# Altium schematic sheet MCU.SchDoc
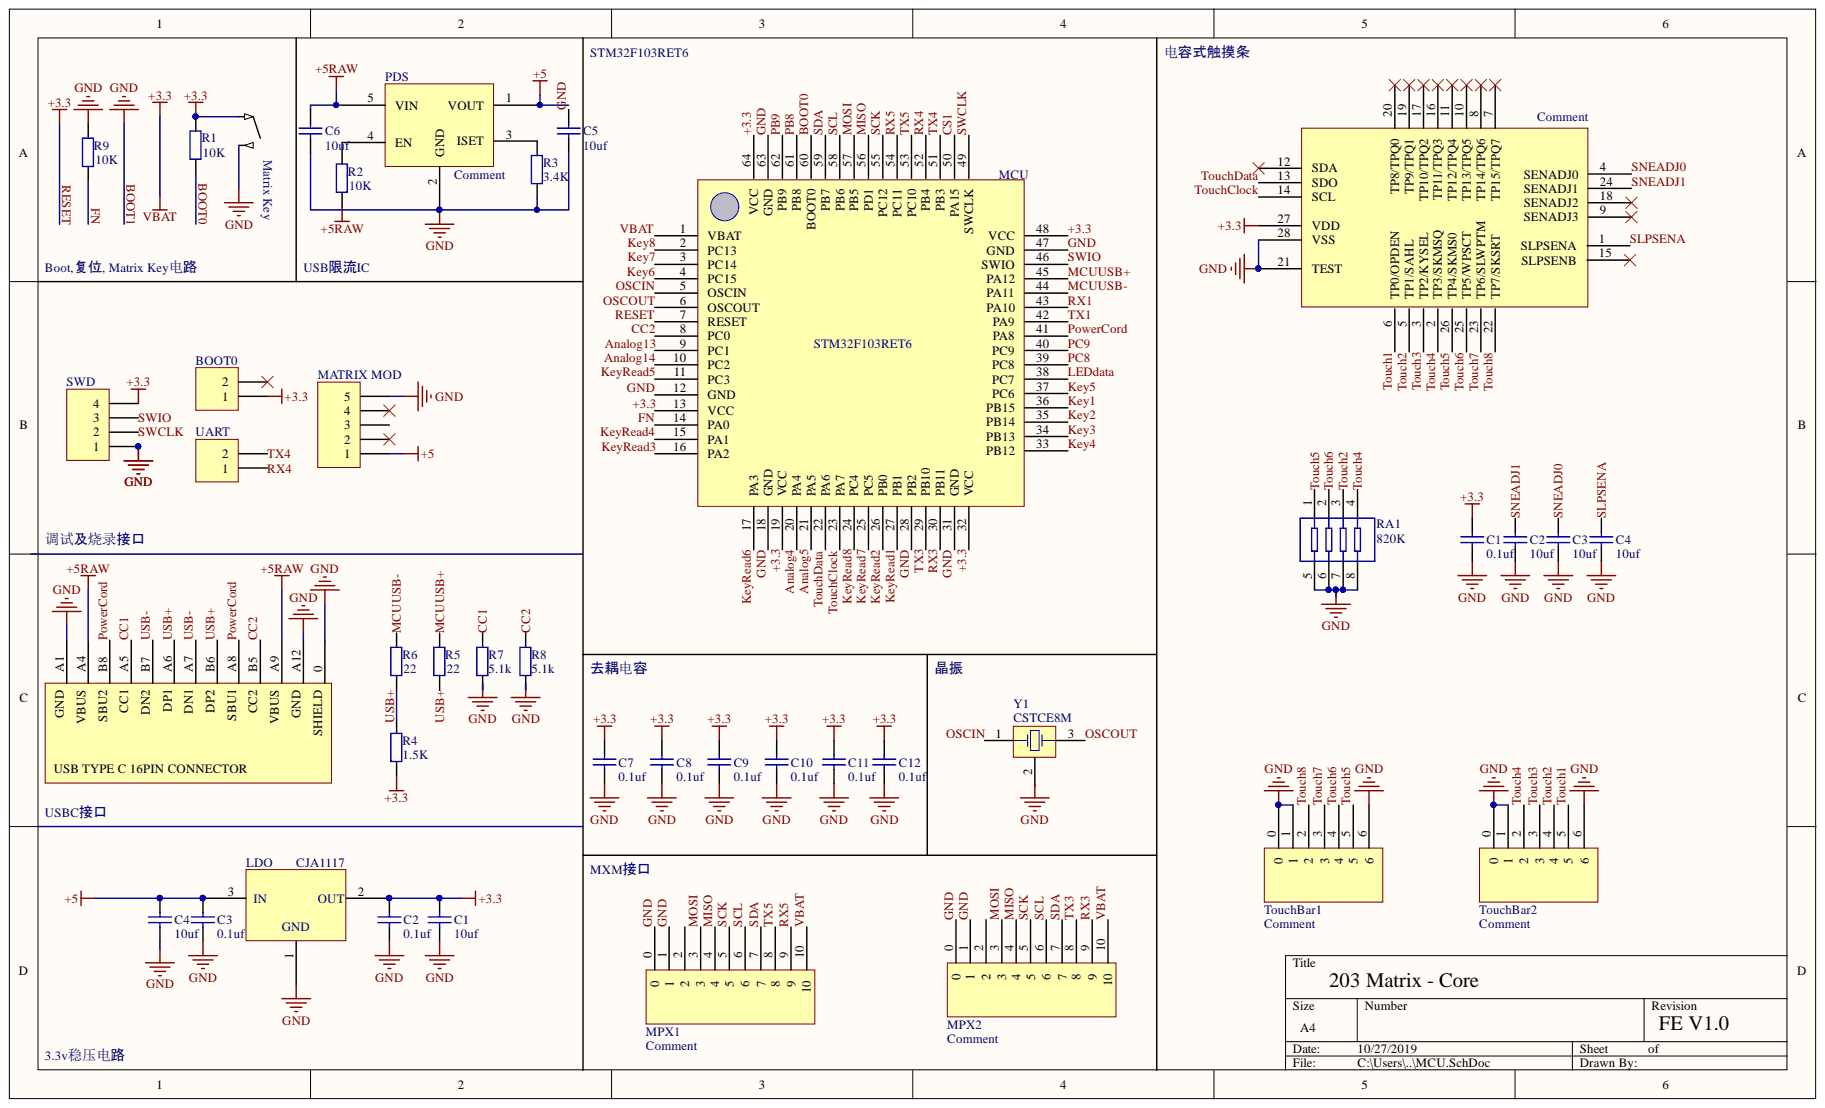
[diagram p0: MCU.SchDoc]

## Parts
BOOT0  MHDR1X2  [MHDR1X2]  (value not drawn)
C1  value 0.1uf  [1608[0603]]  (hidden comment: Cap Semi)
C1  value 10uf  [1608[0603]]  (hidden comment: System)
C2  value 10uf  [1608[0603]]  (hidden comment: 50PF NR0)
C2  value 0.1uf  [1608[0603]]  (hidden comment: System)
C3  value 10uf  [1608[0603]]  (hidden comment: 50PF NR0)
C3  value 0.1uf  [1608[0603]]  (hidden comment: System)
C4  value 10uf  [1608[0603]]  (hidden comment: 50PF NR0)
C4  value 10uf  [1608[0603]]  (hidden comment: System)
C5  value 10uf  [1608[0603]]  (hidden comment: System)
C6  value 10uf  [1608[0603]]  (hidden comment: System)
C7  value 0.1uf  [1608[0603]]  (hidden comment: System)
C8  value 0.1uf  [1608[0603]]  (hidden comment: System)
C9  value 0.1uf  [1608[0603]]  (hidden comment: System)
C10  value 0.1uf  [1608[0603]]  (hidden comment: System)
C11  value 0.1uf  [1608[0603]]  (hidden comment: System)
C12  value 0.1uf  [1608[0603]]  (hidden comment: System)
LDO  CJA1117  [SOT89-3L]
Matrix_Key  Comment  [sw5x5]  (value not drawn)
MATRIX_MOD  MHDR1X5  [MHDR1X5]  (value not drawn)
MCU  RET6  [STM-LQFP64_L]
MPX1  Comment  [10P FPC 0.5mm]
MPX2  Comment  [10P FPC 0.5mm]
PDS  Comment  [SOT23-5]
R1  value 10K  [1608[0603]]  (hidden comment: Res2)
R2  value 10K  [1608[0603]]  (hidden comment: Res2)
R3  value 3.4K  [1608[0603]]  (hidden comment: Res2)
R4  value 1.5K  [1608[0603]]  (hidden comment: System)
R5  value 22  [1608[0603]]  (hidden comment: System)
R6  value 22  [1608[0603]]  (hidden comment: System)
R7  value 5.1k  [1608[0603]]  (hidden comment: System)
R8  value 5.1k  [1608[0603]]  (hidden comment: System)
R9  value 10K  [1608[0603]]  (hidden comment: Res2)
RA1  820K  [8P4R]
SWD  SWD  [HDR1X4]
TOUCH_IC  Comment  [SSOP-28]
TouchBar1  Comment  [6Pin FPC]
TouchBar2  Comment  [6Pin FPC]
UART  Comment  [HDR1X2]  (value not drawn)
USB-C  USBC 16PIN  [USBC 16PIN -1.6]
Y1  CSTCE8M  [CSTCE]

## Nets
+5: C3.2 C4.2 LDO.3 MATRIX_MOD.1 PDS.1(VOUT)
+5RAW: C6.1 PDS.5(VIN) R2.1 USB-C.A4(VBUS) USB-C.A9(VBUS)
+3.3: BOOT0.1 C1.2 C2.2 C7.2 C8.2 C9.2 C10.2 C11.2 C12.2 LDO.2 Matrix_Key.1 MCU.1(VBAT) MCU.7(RESET) MCU.13(VCC) MCU.19(VCC) MCU.32(VCC) MCU.48(VCC) MCU.64(VCC) MPX1.10 MPX2.10 R1.2 R4.2 SWD.4 TOUCH_IC.27(VDD)
Analog4: MCU.20(PA4)
Analog5: MCU.21(PA5)
Analog13: MCU.9(PC1)
Analog14: MCU.10(PC2)
BOOT0: MCU.60(BOOT0) R1.1
BOOT1: C1.1 C2.1 C3.1 C4.1 C5.1 C5.2 C6.2 C7.1 C8.1 C9.1 C10.1 C11.1 C12.1 LDO.1 Matrix_Key.2 MATRIX_MOD.5 MCU.12(GND) MCU.18(GND) MCU.28(PB2) MCU.31(GND) MCU.47(GND) MCU.63(GND) MPX1.0 MPX1.1 MPX2.0 MPX2.1 PDS.2(GND) R3.2 R7.2 R8.2 R9.2 RA1.5 RA1.6 RA1.7 RA1.8 SWD.1 TOUCH_IC.21(TEST) TOUCH_IC.28(VSS) TouchBar1.0 TouchBar1.1 TouchBar1.6 TouchBar2.0 TouchBar2.1 TouchBar2.6 USB-C.0(SHIELD) USB-C.A1(GND) USB-C.A12(GND)
CC1: R7.1 USB-C.A5(CC1)
CC2: MCU.8(PC0) R8.1 USB-C.B5(CC2)
CS1: MCU.50(PA15)
FN: MCU.14(PA0) R9.1
Key1: MCU.36(PB15)
Key2: MCU.35(PB14)
Key3: MCU.34(PB13)
Key4: MCU.33(PB12)
Key5: MCU.37(PC6)
Key6: MCU.4(PC15)
Key7: MCU.3(PC14)
Key8: MCU.2(PC13)
KeyRead1: MCU.27(PB1)
KeyRead2: MCU.26(PB0)
KeyRead3: MCU.16(PA2)
KeyRead4: MCU.15(PA1)
KeyRead5: MCU.11(PC3)
KeyRead6: MCU.17(PA3)
KeyRead7: MCU.25(PC5)
KeyRead8: MCU.24(PC4)
LEDdata: MCU.38(PC7)
MCUUSB+: MCU.45(PA12) R5.2
MCUUSB-: MCU.44(PA11) R6.2
MISO: MCU.56(PD1) MPX1.4 MPX2.4
MOSI: MCU.57(PB5) MPX1.3 MPX2.3
OSCIN: MCU.5(OSCIN) Y1.1
OSCOUT: MCU.6(OSCOUT) Y1.3
PB8: MCU.61(PB8)
PB9: MCU.62(PB9)
PC8: MCU.39(PC8)
PC9: MCU.40(PC9)
PowerCord: MCU.41(PA8) USB-C.A8(SBU1) USB-C.B8(SBU2)
RX1: MCU.43(PA10)
RX3: MCU.30(PB11) MPX2.9
RX4: MCU.52(PB4) UART.1
RX5: MCU.54(PC11) MPX1.9
SCK: MCU.55(PC12) MPX1.5 MPX2.5
SCL: MCU.58(PB6) MPX1.6 MPX2.6
SDA: MCU.59(PB7) MPX1.7 MPX2.7
SLPSENA: C4.2 TOUCH_IC.1(SLPSENA)
SNEADJ0: C3.2 TOUCH_IC.4(SENADJ0)
SNEADJ1: C2.2 TOUCH_IC.24(SENADJ1)
SWCLK: MCU.49(SWCLK) SWD.2
SWIO: MCU.46(SWIO) SWD.3
Touch1: TOUCH_IC.6(TP0/OPDEN) TouchBar2.5
Touch2: RA1.3 TOUCH_IC.5(TP1/SAHL) TouchBar2.4
Touch3: TOUCH_IC.3(TP2/KYSEL) TouchBar2.3
Touch4: RA1.4 TOUCH_IC.2(TP3/SKMSQ) TouchBar2.2
Touch5: RA1.1 TOUCH_IC.26(TP4/SKMS0) TouchBar1.5
Touch6: RA1.2 TOUCH_IC.25(TP5/WPSCT) TouchBar1.4
Touch7: TOUCH_IC.23(TP6/SLWPTM) TouchBar1.3
Touch8: TOUCH_IC.22(TP7/SKSRT) TouchBar1.2
TouchClock: MCU.23(PA7) TOUCH_IC.14(SCL)
TouchData: MCU.22(PA6) TOUCH_IC.13(SDO)
TX1: MCU.42(PA9)
TX3: MCU.29(PB10) MPX2.8
TX4: MCU.51(PB3) UART.2
TX5: MCU.53(PC10) MPX1.8
USB+: R4.1 R5.1 R6.1 USB-C.A6(DP1) USB-C.B6(DP2)
USB-: USB-C.A7(DN1) USB-C.B7(DN2)
NetPDS_3: PDS.3(ISET) R3.2
NetPDS_4: PDS.4(EN) R2.2
N00182:
N00183:
N00184:
N00185:

Not connected: BOOT0 2; MATRIX_MOD 2 3 4; MPX1 2; MPX2 2; TOUCH_IC 7(TP15/TPQ7) 8(TP14/TPQ6) 9(SENADJ3) 10(TP13/TPQ5) 11(TP12/TPQ4) 12(SDA) 15(SLPSENB) 16(TP11/TPQ3) 17(TP10/TPQ2) 18(SENADJ2) 19(TP9/TPQ1) 20(TP8/TPQ0); Y1 2

## Library data of the parts
BOOT0 UART = MHDR1X2: Header, 2-Pin; Component Kind: Standard; LatestRevisionDate: 17-Jul-2002; LatestRevisionNote: Re-released for DXP Platform.; Library Name: Miscellaneous Connectors.IntLib; Signal Integrity: Connector; Publisher: Altium Limited
C1-C4 = Cap: System; Component Kind: Standard; LatestRevisionDate: 17-Jul-2002; LatestRevisionNote: Re-released for DXP Platform.; Library Name: Miscellaneous Devices.IntLib; PackageDescription: Radial Cap, Thru-Hole; 2 Leads; 0.3 in Pin Spacing; PackageReference: RAD-0.3; Published: 8-Jun-2000; Signal Integrity: Cap; Simulation: CAP; Publisher: Altium Limited
C1-C12 = Cap: Component Kind: Standard; Description: System; LatestRevisionDate: 17-Jul-2002; LatestRevisionNote: Re-released for DXP Platform.; Library Name: Miscellaneous Devices.IntLib; PackageDescription: Radial Cap, Thru-Hole; 2 Leads; 0.3 in Pin Spacing; PackageReference: RAD-0.3; Published: 8-Jun-2000; Signal Integrity: Cap; Simulation: CAP; Publisher: Altium Limited
Matrix_Key = SW: System; Component Kind: Standard; Library Name: 203.IntLib
MATRIX_MOD = MHDR1X5: Header, 5-Pin; Component Kind: Standard; LatestRevisionDate: 17-Jul-2002; LatestRevisionNote: Re-released for DXP Platform.; Library Name: Miscellaneous Connectors.IntLib; Signal Integrity: Connector; Publisher: Altium Limited
MPX1 MPX2 = 10 pin fpc: Component Kind: Standard; Library Name: 203.IntLib
PDS = PL2700: Component Kind: Standard; Library Name: 203.IntLib
R1-R3 R9 = Res2: System; Component Kind: Standard; LatestRevisionDate: 17-Jul-2002; LatestRevisionNote: Re-released for DXP Platform.; Library Name: Miscellaneous Devices.IntLib; PackageDescription: Axial Device, Thru-Hole; 2 Leads; 0.4 in Pin Spacing; PackageReference: AXIAL-0.4; Published: 8-Jun-2000; Signal Integrity: Res; Simulation: RESISTOR; Publisher: Altium Limited
R4-R8 = Res2: Component Kind: Standard; Description: System; LatestRevisionDate: 17-Jul-2002; LatestRevisionNote: Re-released for DXP Platform.; Library Name: Miscellaneous Devices.IntLib; PackageDescription: Axial Device, Thru-Hole; 2 Leads; 0.4 in Pin Spacing; PackageReference: AXIAL-0.4; Published: 8-Jun-2000; Signal Integrity: Res; Simulation: RESISTOR; Publisher: Altium Limited
RA1 = 4R8P Resistor: Component Kind: Standard; Library Name: 203.IntLib
SWD = Header 4: System; Component Kind: Standard; LatestRevisionDate: 17-Jul-2002; LatestRevisionNote: Re-released for DXP Platform.; Library Name: Miscellaneous Connectors.IntLib; Signal Integrity: Connector; Publisher: Altium Limited
TOUCH_IC = TTP229: Component Kind: Standard; Library Name: 203.IntLib
TouchBar1 TouchBar2 = 6 pin fpc: Component Kind: Standard; Library Name: 203.IntLib
USB-C = USBC 16PIN: System; Component Kind: Standard; Library Name: 203.IntLib
Y1 = 3225Crystal_1: Component Kind: Standard; Library Name: 203.IntLib
LDO = CJA1117: Component Kind: Standard; Library Name: 203.IntLib
MCU = RET6: Component Kind: Standard; Library Name: 203.IntLib
